# Altium schematic sheet Connectors.SchDoc
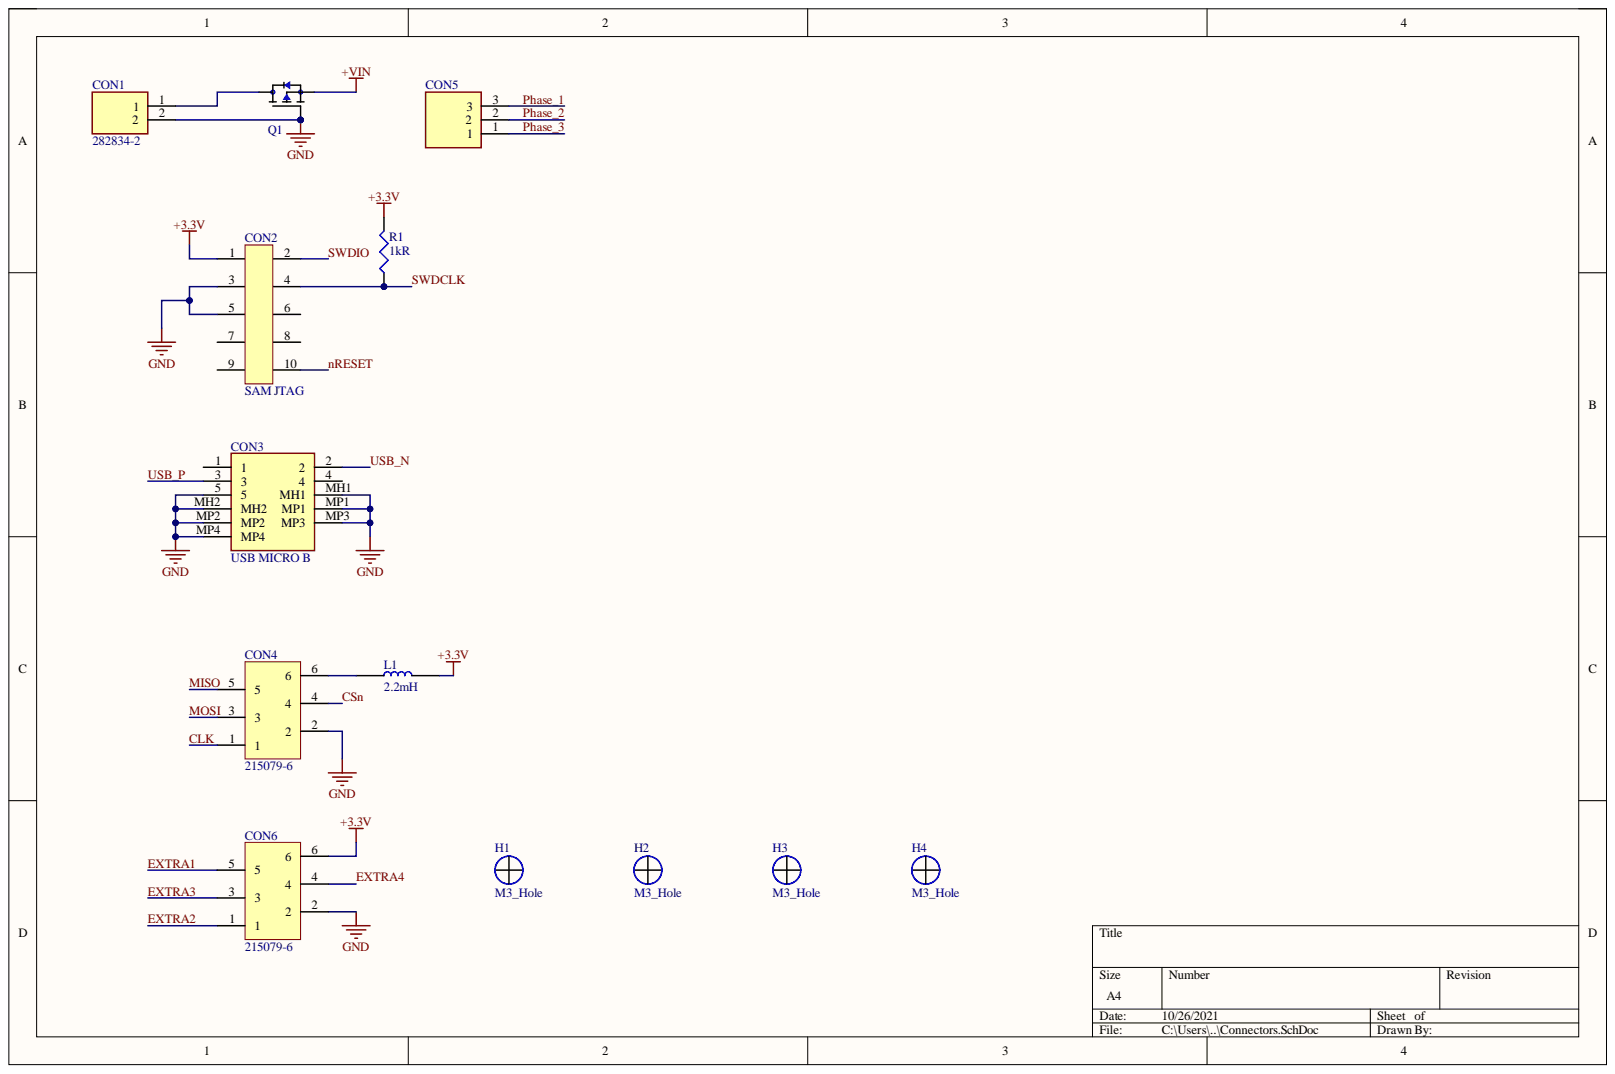
[diagram p0: Connectors.SchDoc]

## Parts
CON1  282834-2  [282834-2_1]
CON2  SAM JTAG  [FCI_20021111-00010T4LF]
CON3  USB MICRO B  [101181930001LF]
CON4  215079-6  [215079-6]
CON5  3pin Connector  [TE_282834-3]  (value not drawn)
CON6  215079-6  [215079-6]
H1  M3_Hole  [M3_Hole]
H2  M3_Hole  [M3_Hole]
H3  M3_Hole  [M3_Hole]
H4  M3_Hole  [M3_Hole]
L1  2.2mH  [Inductor SRR6603-222ML]
Q1  IPD80P03P4L07ATMA1  [DPAK228P994X240-4N]  (value not drawn)
R1  1kR  [0402_RES_RESC1005X40N]

## Nets
+3.3V: CON2.1 CON6.6 L1.2 R1.2
+VIN: Q1.3
GND: CON1.2 CON2.3 CON2.5 CON3.5 CON3.MH1 CON3.MH2 CON3.MP1 CON3.MP2 CON3.MP3 CON3.MP4 CON4.2 CON6.2 Q1.1
CLK: CON4.1
CSn: CON4.4
EXTRA1: CON6.5
EXTRA2: CON6.1
EXTRA3: CON6.3
EXTRA4: CON6.4
MISO: CON4.5
MOSI: CON4.3
nRESET: CON2.10
Phase_1: CON5.3
Phase_2: CON5.2
Phase_3: CON5.1
SWDCLK: CON2.4 R1.1
SWDIO: CON2.2
USB_N: CON3.2
USB_P: CON3.3
NetCON1_1: CON1.1 Q1.4
NetCON4_6: CON4.6 L1.1

Not connected: CON2 6 7 8 9; CON3 1 4; H1 1; H2 1; H3 1; H4 1

## Library data of the parts
CON1 = 282834-2: Connector; Manufacturer_Name: TE Connectivity; Allied_Number: 70086732; Allied Price/Stock: http://www.alliedelec.com/te-connectivity-282834-2/70086732/; Component Kind: Standard; Datasheet Link: http://www.te.com/commerce/DocumentDelivery/DDEController?Action=showdoc&DocId=Catalog+Section%7F1308389_EUROSTYLE_TERMINAL_BLOCKS%7F0607%7Fpdf%7FEnglish%7FENG_CS_1308389_EUROSTYLE_TERMINAL_BLOCKS_0607.pdf%7F282834-2.pdf; Library Name: Schlib1.SchLib; Manufacturer_Part_Number: 282834-2; Mouser Part Number: 571-282834-2; Mouser Price/Stock: https://www.mouser.com/Search/Refine.aspx?Keyword=571-282834-2; RS Part Number: 7100104P; RS Price/Stock: http://uk.rs-online.com/web/p/products/7100104P
CON2 = 20021111-00010T4LF: CONN HEADER VERT 10POS 1.27MM; Manufacturer 1: Amphenol FCI; Connector Type: Male Pin,Header; Contact Post Length: 2.3mm; Contact Resistance: 30mR; Current Rating: 10A; Gender: Male; Insulation Resistance: 100MR; Max Voltage Rating (AC): 125V; Number of Contacts: 10; Row Spacing: 0.05inch; Stack Height: 2.5mm; Color: Black; Component Kind: Standard; ComponentLink1Description: https://datasheet.ciiva.com/1433/1079612-1433267.pdf?src-supplier=Element14; ComponentLink1URL: https://datasheet.ciiva.com/1433/1079612-1433267.pdf?src-supplier=Element14; ComponentLink2Description: https://datasheet.ciiva.com/409/20021111-409158.pdf?src-supplier=Digi-Key; ComponentLink2URL: https://datasheet.ciiva.com/409/20021111-409158.pdf?src-supplier=Digi-Key; ComponentLink3Description: https://datasheet.ciiva.com/5131/20021111-5131386.pdf?src-supplier=Mouser; ComponentLink3URL: https://datasheet.ciiva.com/5131/20021111-5131386.pdf?src-supplier=Mouser; ComponentLink4Description: https://datasheet.ciiva.com/9465/20021111-9465402.pdf?src-supplier=Avnet; ComponentLink4URL: https://datasheet.ciiva.com/9465/20021111-9465402.pdf?src-supplier=Avnet; Contact Material: Nickel,Copper,Copper Alloy,Gold; Contact Mating Length: 0.12inch; Contact Plating: Gold; Depth: 3.4mm; Housing Color: Black; Housing Material: Plastic; Lead Free: Lead Free; Length: 6.35mm; Library Name: Schlib1.SchLib; Manufacturer Part Number 1: 20021111-00010T4LF; Max Operating Temperature: 85°C; Min Operating Temperature: -40°C; Mount: Through Hole; Number of Positions: 10; Number of Rows: 2; Orientation: Straight; Package Quantity: 93; Packaging: Rail/Tube; Pitch: 1.27mm; Pricing 1: 1=0,69000, 10=0,65100, 100=0,49890, 500=0,43380, 1000=0,35789, 5000=0,31451, 10000=0,29282 (USD); Radiation Hardening: No; REACH SVHC: No SVHC; RoHS: Yes; Stock 1: 7271; Supplier 1: Digi-Key; Supplier Part Number 1: 609-3712-ND; Termination: Solder; Voltage Rating: 125V
CON3 = 10118193-0001LF: Manufacturer_Name: Amphenol; Allied_Number: 70236827; Allied Price/Stock: https://www.alliedelec.com/amphenolicc-10118193-0001lf/70236827/; Component Kind: Standard; Datasheet Link: https://componentsearchengine.com/Datasheets/1/10118193-0001LF.pdf; Description: USB Micro B; Height: 3.1mm; Library Name: Schlib1.SchLib; Manufacturer_Part_Number: 10118193-0001LF; Mouser Part Number: 649-10118193-0001LF; Mouser Price/Stock: https://www.mouser.com/Search/Refine.aspx?Keyword=649-10118193-0001LF; RS Part Number: 8632989; RS Price/Stock: http://uk.rs-online.com/web/p/products/8632989
CON4 CON6 = 215079-6: CONNECTOR, RCPT, 6POS, 2ROW, 1.27MM; Product Range:Micro-MaTch Series; Contact Termination Type:Through Hole; Gender:Receptacle; No. of Contacts:6; No. of Rows:2; Pitch Spacing:1.27mm; Contact Plating:Tin; Contact Material:Phosphor ;RoHS Compliant: Yes; Manufacturer 1: TE Connectivity; Board Standoff: No (Without); Connector System: Board-to-Board; Connector Type: Receptacle,Connector,Pin; Contact Current Rating: 1.5A; Contact Post Length: 0.122inch; Insulation Resistance: 1GR; Max Voltage Rating (DC): 100V; Number of Contacts: 6; PCB Mount Retention: With; PCB Mounting Orientation: Vertical; Pitch: 0.05inch; Plating Thickness: 0.005um; Row Spacing: 0.1inch; Shrouded: Yes (With); Voltage Rating: 230V; Color: Red; Component Kind: Standard; ComponentLink1Description: https://datasheet.ciiva.com/16310/eng-cs-82012-ribbon-cable-interconnect-sol-1109-16310643.pdf?src-supplier=Future+Electronics; ComponentLink1URL: https://datasheet.ciiva.com/16310/eng-cs-82012-ribbon-cable-interconnect-sol-1109-16310643.pdf?src-supplier=Future+Electronics; ComponentLink2Description: https://datasheet.ciiva.com/11745/0900766b80d910b9-11745546.pdf?src-supplier=RSComponents; ComponentLink2URL: https://datasheet.ciiva.com/11745/0900766b80d910b9-11745546.pdf?src-supplier=RSComponents; ComponentLink3Description: https://datasheet.ciiva.com/15176/getdatasheetpartid-309076-15176639.pdf?src-supplier=Verical; ComponentLink3URL: https://datasheet.ciiva.com/15176/getdatasheetpartid-309076-15176639.pdf?src-supplier=Verical; ComponentLink4Description: https://datasheet.ciiva.com/16468/eng-cs-82012-ribbon-cable-interconnect-sol-0412-16468824.pdf?src-supplier=Avnet+Asia; ComponentLink4URL: https://datasheet.ciiva.com/16468/eng-cs-82012-ribbon-cable-interconnect-sol-0412-16468824.pdf?src-supplier=Avnet+Asia; ComponentLink5Description: https://datasheet.ciiva.com/1438/eng-cs-82012-ribbon-cable-interconnect-sol-0412-1438794.pdf?src-supplier=Element14; ComponentLink5URL: https://datasheet.ciiva.com/1438/eng-cs-82012-ribbon-cable-interconnect-sol-0412-1438794.pdf?src-supplier=Element14; ComponentLink6Description: https://datasheet.ciiva.com/16660/eng-cs-82012-ribbon-cable-interconnect-sol-0412-16660347.pdf?src-supplier=Avnet+Europe; ComponentLink6URL: https://datasheet.ciiva.com/16660/eng-cs-82012-ribbon-cable-interconnect-sol-0412-16660347.pdf?src-supplier=Avnet+Europe; ComponentLink7Description: https://datasheet.ciiva.com/30156/eng-cat-889409-0699-96-30156959.pdf?src-supplier=Onlinecomponents.com; ComponentLink7URL: https://datasheet.ciiva.com/30156/eng-cat-889409-0699-96-30156959.pdf?src-supplier=Onlinecomponents.com; Contact Gender: Female; Contact Material: Bronze; Contact Plating: Tin; Current Rating: 1.5A; Depth: 5.1mm; ELV: Compliant; Flammability Rating: UL94 V-0; Gender: Receptacle; Height: 0.16inch; Housing Color: Red; Housing Material: Polyester; Lead Free: Lead Free; Lead Pitch: 1.27mm; Length: 0.382inch; Library Name: Schlib1.SchLib; Manufacturer Part Number 1: 215079-6; Mating Alignment: No (Without); Mating Retention: No (Without); Max Operating Temperature: 105°C; Min Operating Temperature: -40°C; Mount: Through Hole; Number of Positions: 6; Number of Rows: 2; Orientation: Straight; Package Quantity: 2500; Packaging: Tape and Reel; PCB Mount Alignment: No (Without); Pricing 1: 1=0,52000, 10=0,49200, 100=0,37690, 500=0,32774, 1000=0,27039 (USD); Radiation Hardening: No; REACH SVHC: No SVHC; RoHS: Yes; Stock 1: 4419; Supplier 1: Digi-Key; Supplier Part Number 1: A105004CT-ND; Termination: Through Hole
CON5 = 282834-3: 3 Position Wire to Board Terminal Block Horizontal with Board 0.100 _2.54mm_; MF: TE Connectivity; Component Kind: Standard; Digi-Key_Part_Number: A98334-ND; Digi-Key_Purchase_URL: https://www.digikey.cz/product-detail/en/te-connectivity-amp-connectors/282834-3/A98334-ND/1153264?utm_source=snapeda&utm_medium=aggregator&utm_campaign=symbol; EU_RoHS_Compliance: Compliant with Exemptions; Library Name: Schlib1.SchLib; MP: 282834-3; Package: None; SnapEDA_Link: https://www.snapeda.com/parts/282834-3/TE%20Connectivity%20AMP%20Connectors/view-part/?ref=snap; TE_purchase_URL: https://www.te.com/usa-en/product-282834-3.html?te_bu=Cor&te_type=disp&te_campaign=seda_glo_cor-seda-global-disp-prtnr-fy19-seda-model-bom-cta_sma-317_1&elqCampaignId=32493
L1 = SRR6603-222ML: Component Kind: Standard; Library Name: Schlib1.SchLib
Q1 = IPD80P03P4L07ATMA1: MOSFET P-CH 30V 80A TO252-3; Manufacturer 1: Infineon; Drain to Source Resistance: 6.8mR; Drain to Source Voltage (Vdss): -30V; Element Configuration: Single; Fall Time: 60ns; Gate to Source Voltage (Vgs): 5V; Input Capacitance: 5.7nF; Max Power Dissipation: 88W; Number of Elements: 1; Rise Time: 4ns; Turn-Off Delay Time: 15ns; Turn-On Delay Time: 8ns; Case/Package: TO-252-3; Component Kind: Standard; ComponentLink1Description: https://datasheet.ciiva.com/6371/infineon-ipd80p03p4l-07-ds-v01-00-en-6371894.pdf?src-supplier=Digi-Key; ComponentLink1URL: https://datasheet.ciiva.com/6371/infineon-ipd80p03p4l-07-ds-v01-00-en-6371894.pdf?src-supplier=Digi-Key; ComponentLink2Description: https://datasheet.ciiva.com/6371/infineon-ipd80p03p4l-07-ds-v01-00-en-6371885.pdf?src-supplier=Digi-Key; ComponentLink2URL: https://datasheet.ciiva.com/6371/infineon-ipd80p03p4l-07-ds-v01-00-en-6371885.pdf?src-supplier=Digi-Key; Continuous Drain Current (ID): 80A; Drain to Source Breakdown Voltage: 30V; Halogen Free: Halogen Free; Library Name: Schlib1.SchLib; Manufacturer Part Number 1: IPD80P03P4L07ATMA1; Max Dual Supply Voltage: -30V; Max Operating Temperature: 175°C; Min Operating Temperature: -55°C; Number of Pins: 3; Package Quantity: 1; Packaging: Tape and Reel; Power Dissipation: 88W; Pricing 1: 1=1,47000, 10=1,31800, 100=0,93790, 500=0,79496, 1000=0,64758 (USD); Rds On Max: 6.8mR; RoHS: Yes; Stock 1: 4630; Supplier 1: Digi-Key; Supplier Part Number 1: IPD80P03P4L07ATMA1CT-ND
R1 = CRCW04021K00FKEDHP: RES SMD 1K OHM 1% 1/5W 0402; Manufacturer 1: Vishay Dale; Max Power Dissipation: 125mW; Temperature Coefficient: 100ppm/°C; Voltage Rating: 50V; Case Code (Imperial): 0402; Case Code (Metric): 1005; Case/Package: 0402; Component Kind: Standard; ComponentLink1Description: https://datasheet.ciiva.com/5545/crcwhpe3-46056-5545653.pdf?src-supplier=Mouser; ComponentLink1URL: https://datasheet.ciiva.com/5545/crcwhpe3-46056-5545653.pdf?src-supplier=Mouser; ComponentLink2Description: https://datasheet.ciiva.com/2498/crcwhpe3-2498728.pdf?src-supplier=Digi-Key; ComponentLink2URL: https://datasheet.ciiva.com/2498/crcwhpe3-2498728.pdf?src-supplier=Digi-Key; ComponentLink3Description: https://datasheet.ciiva.com/16436/crcwhpe3-16436184.pdf?src-supplier=Future+Electronics; ComponentLink3URL: https://datasheet.ciiva.com/16436/crcwhpe3-16436184.pdf?src-supplier=Future+Electronics; ComponentLink4Description: https://datasheet.ciiva.com/2498/crcwhpe3-2498729.pdf?src-supplier=Digi-Key; ComponentLink4URL: https://datasheet.ciiva.com/2498/crcwhpe3-2498729.pdf?src-supplier=Digi-Key; ComponentLink5Description: https://datasheet.ciiva.com/2498/crcwhpe3-2498730.pdf?src-supplier=Digi-Key; ComponentLink5URL: https://datasheet.ciiva.com/2498/crcwhpe3-2498730.pdf?src-supplier=Digi-Key; ComponentLink6Description: https://datasheet.ciiva.com/28621/1750852-28621339.pdf?src-supplier=Element14; ComponentLink6URL: https://datasheet.ciiva.com/28621/1750852-28621339.pdf?src-supplier=Element14; ComponentLink7Description: https://datasheet.ciiva.com/3256/crcwhpe3-3256142.pdf?src-supplier=Avnet; ComponentLink7URL: https://datasheet.ciiva.com/3256/crcwhpe3-3256142.pdf?src-supplier=Avnet; Composition: Thick Film; Contact Plating: Tin; Depth: 0.5mm; Features: Automotive AEC-Q200; Height: 0.3mm; Lead Free: Lead Free; Length: 1mm; Library Name: Schlib1.SchLib; Manufacturer Part Number 1: CRCW04021K00FKEDHP; Max Operating Temperature: 155°C; Military Standard: Not; Min Operating Temperature: -55°C; Mount: Surface Mount; Number of Pins: 2; Number of Terminations: 2; Package Quantity: 10; Packaging: Tape and Reel; Power Rating: 125mW; Pricing 1: 1=0,14000, 10=0,12600, 100=0,04840, 1000=0,02130, 2500=0,01839, 5000=0,01646 (USD); Radiation Hardening: No; REACH SVHC: Unknown; Resistance: 1kR; RoHS: Yes; Stock 1: 404675; Supplier 1: Digi-Key; Supplier Part Number 1: 541-1.00KYCT-ND; Termination: SMD/SMT; Tolerance: 1%; Voltage Rating (DC): 50V; Weight: 2.3E-05oz; Width: 0.5mm
H1-H4 = M3_Hole: Component Kind: Standard; Library Name: Schlib1.SchLib
